# Altium schematic sheet Power Input and Protection for T to E.SchDoc("Power Input and Protection for T to E")
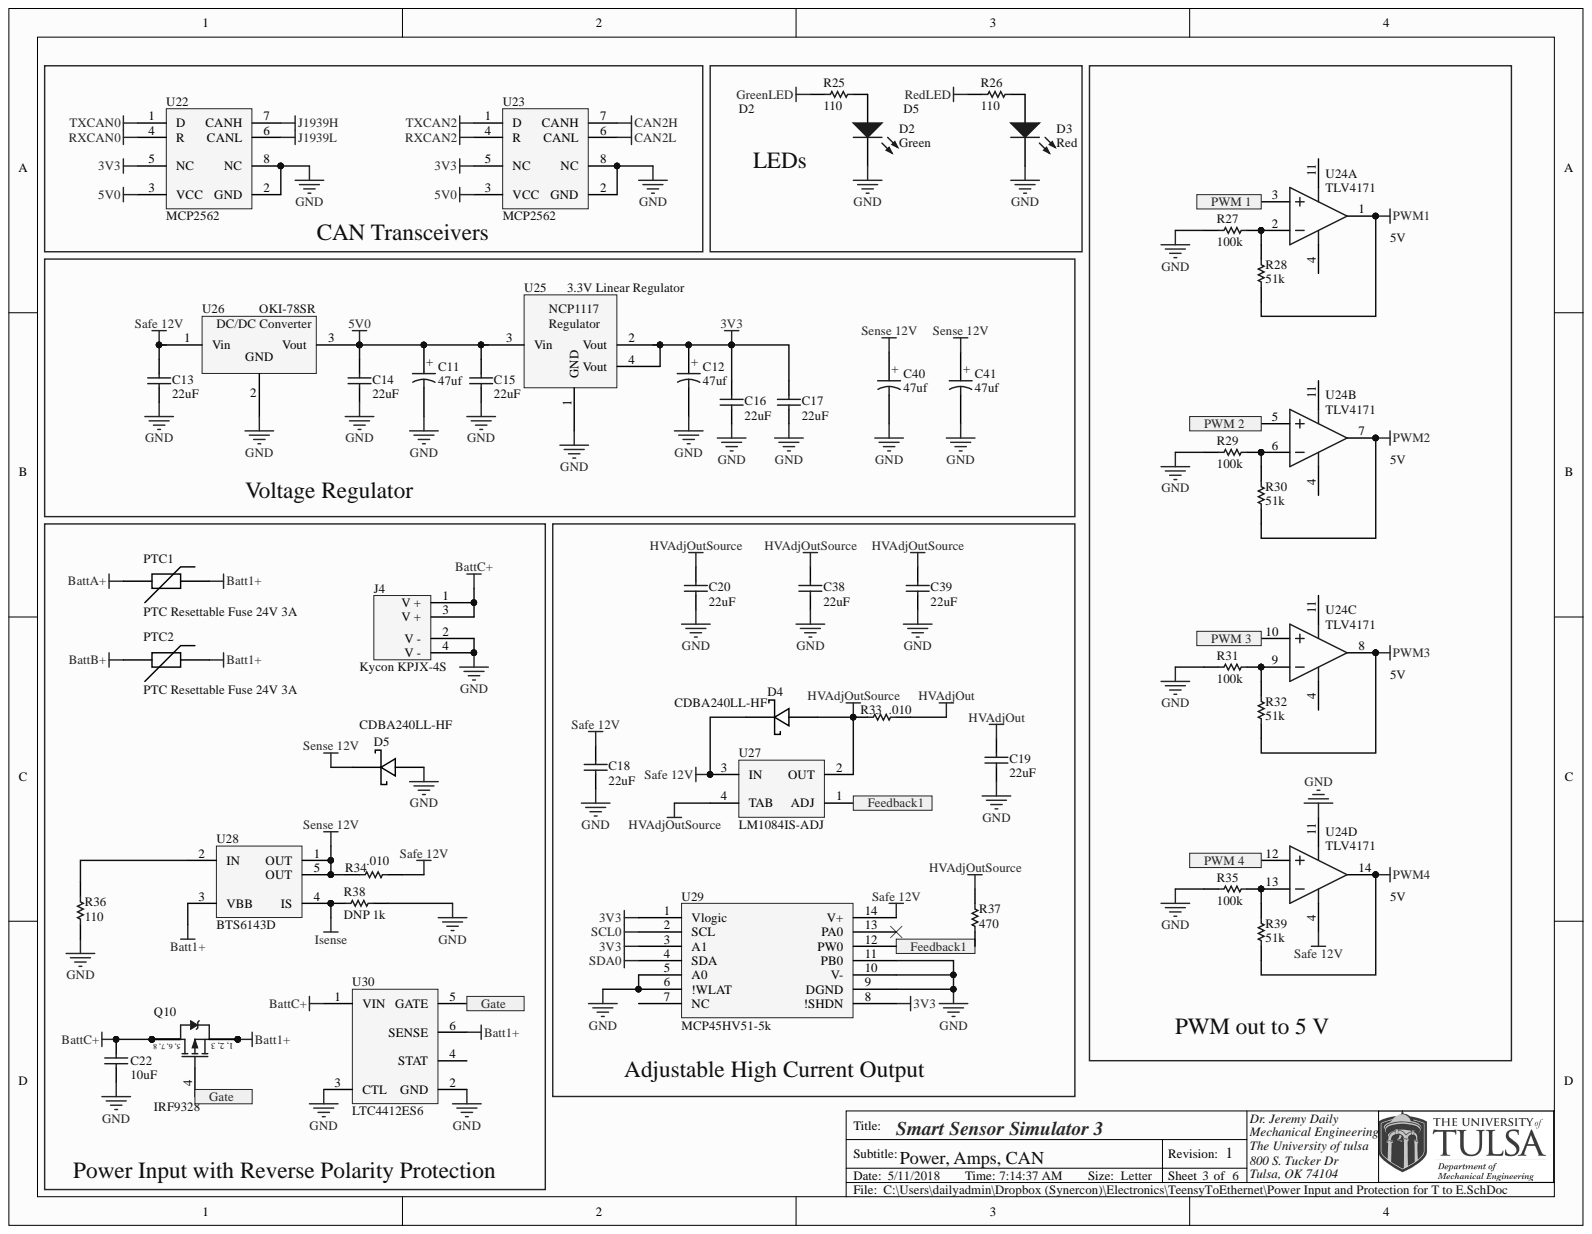
[diagram p0: Power Input and Protection for T to E.SchDoc]

## Parts
C11  47uf  [Elec. Cap 2.5 x 6.3mm]
C12  47uf  [Elec. Cap 2.5 x 6.3mm]
C13  22uF  [1206]
C14  22uF  [1206]
C15  22uF  [1206]
C16  22uF  [1206]
C17  22uF  [1206]
C18  22uF  [1206]
C19  22uF  [1206]
C20  22uF  [1206]
C22  10uF  [0603]
C38  22uF  [1206]
C39  22uF  [1206]
C40  47uf  [Elec. Cap 2.5 x 6.3mm]
C41  47uf  [Elec. Cap 2.5 x 6.3mm]
D2  Green  [0805 Polarized]
D3  Red  [0805 Polarized]
D4  CDBA240LL-HF  [SMA with A and K]
D5  CDBA240LL-HF  [SMA with A and K]
J4  Kycon KPJX-4S  Manufacturer: Kycon  [KPJX-4S-S]
PTC1  PTC Resettable Fuse 24V 3A  [2920]
PTC2  PTC Resettable Fuse 24V 3A  [2920]
Q10  IRF9328  [SOIC-8]
R25  110  [0603]
R26  110  [0603]
R27  100k  [0603]
R28  51k  [0603]
R29  100k  [0603]
R30  51k  [0603]
R31  100k  [0603]
R32  51k  [0603]
R33  .010  [0805]
R34  .010  [0805]
R35  100k  [0603]
R36  110  [0603]
R37  470  [0603]
R38  DNP 1k  [0603]
R39  51k  [0603]
U22  MCP2562  [SN65HVD232]
U23  MCP2562  [SN65HVD232]
U24  TLV4171  [TI-PW14_M]  (parts A,B,C,D)
U25  3.3V Linear Regulator  [SOT-223]
U26  OKI-78SR  [78xx]
U27  LM1084IS-ADJ  [TS3B_M]
U28  BTS6143D  [INF-PG-TO252-5-11_N]
U29  MCP45HV51-5k  [TSSOP-ST14_M]
U30  LTC4412ES6  [LT-S6-6-TSOT-23_M]

## Ports
Feedback1, Gate, PWM 1, PWM 2, PWM 3, PWM 4

## Nets
3V3: C12.1 C16.2 C17.2 U22.5(NC) U23.5(NC) U25.2(Vout) U25.4(Vout) U29.1(Vlogic) U29.3(A1) U29.8(!SHDN)
5V0: C11.1 C14.2 C15.2 U22.3(VCC) U23.3(VCC) U25.3(Vin) U26.3
Batt1+: PTC1.2 PTC2.2 Q10.1 Q10.2 Q10.3 U28.3(VBB) U30.6(SENSE)
BattA+: PTC1.1
BattB+: PTC2.1
BattC+: C22.1 J4.1(V_+) J4.3(V_+) Q10.5 Q10.6 Q10.7 Q10.8 U30.1(VIN)
CAN2H: U23.7(CANH)
CAN2L: U23.6(CANL)
GND: C11.2 C12.2 C13.1 C14.1 C15.1 C16.1 C17.1 C18.1 C19.1 C20.1 C22.2 C38.1 C39.1 C40.2 C41.2 D2.2 D3.2 D5.1 J4.2(V_-) J4.4(V_-) R27.2 R29.2 R31.2 R35.2 R36.1 R38.1 U22.2(GND) U22.8(NC) U23.2(GND) U23.8(NC) U24.11 U25.1(GND) U26.2 U29.5(A0) U29.6(!WLAT) U29.9(DGND) U29.10(V-) U29.11(PB0) U30.2(GND) U30.3(CTL)
GreenLED: R25.2
HVAdjOut: C19.2 R33.1
HVAdjOutSource: C20.2 C38.2 C39.2 D4.1 R33.2 R37.1 U27.2(OUT) U27.4(TAB)
Isense: R38.2 U28.4(IS)
J1939H: U22.7(CANH)
J1939L: U22.6(CANL)
PWM1: R28.2 U24.1
PWM2: R30.2 U24.7
PWM3: R32.2 U24.8
PWM4: R39.2 U24.14
RedLED: R26.2
RXCAN0: U22.4(R)
RXCAN2: U23.4(R)
Safe 12V: C13.2 C18.2 D4.2 R34.1 U24.4 U26.1 U27.3(IN) U29.14(V+)
SCL0: U29.2(SCL)
SDA0: U29.4(SDA)
Sense 12V: C40.1 C41.1 D5.2 R34.2 U28.1(OUT) U28.5(OUT)
TXCAN0: U22.1(D)
TXCAN2: U23.1(D)
Feedback1: R37.2 U27.1(ADJ) U29.12(PW0) ; port Feedback1
Gate: Q10.4 U30.5(GATE) ; port Gate
PWM 1: U24.3 ; port PWM 1
PWM 2: U24.5 ; port PWM 2
PWM 3: U24.10 ; port PWM 3
PWM 4: U24.12 ; port PWM 4
NetD2_1: D2.1 R25.1
NetD3_1: D3.1 R26.1
NetR27_1: R27.1 R28.1 U24.2
NetR29_1: R29.1 R30.1 U24.6
NetR31_1: R31.1 R32.1 U24.9
NetR35_1: R35.1 R39.1 U24.13
NetR36_2: R36.2 U28.2(IN)

Not connected: U29 7(NC) 13(PA0); U30 4(STAT)

## Library data of the parts
C11 C12 C40 C41 = Electrolytic Cap: 47µF 50V Aluminum Capacitors Radial, Can 5000 Hrs @ 105°C; PartNumber: EEU-EB1H470S; Manufacturer: Panasonic Electronic Components; Alternative: Acceptable; Supplier Part Number 1: P13464-ND; Supplier 1: Digi-Key; Library Name: SSS Integrated Library.IntLib; Component Kind: Standard
C13-C20 C38 C39 = Cap: 22µF ±20% 25V Ceramic Capacitor X5R 1206 (3216 Metric); PartNumber: 12063D226MAT2A; Manufacturer: AVX Corporation; Alternative: Acceptable; Supplier Part Number 1: 478-10040-1-ND; Supplier 1: Digi-Key; Simulation: CAP; Signal Integrity: Cap; Library Name: SSS Integrated Library.IntLib; Component Kind: Standard
C22 = Cap: 10µF ±20% 25V Ceramic Capacitor X5R 0603 (1608 Metric); PartNumber: ZRB18AR61E106ME01L; Manufacturer: Murata Electronics North America; Alternative: Acceptable; Supplier Part Number 1: 490-10991-1-ND; Supplier 1: Digi-Key; Simulation: CAP; Signal Integrity: Cap; Library Name: SSS Integrated Library.IntLib; Component Kind: Standard
D2 = LED - Green: Green 570nm LED Indication - Discrete 2.2V 0805 (2012 Metric); PartNumber: LG R971-KN-1; Manufacturer: OSRAM Opto Semiconductors Inc.; Alternative: None; Supplier Part Number 1: 475-1410-1-ND; Supplier 1: Digi-Key; Library Name: SSS Integrated Library.IntLib; Component Kind: Standard
D3 = LED - Red: Red 633nm LED Indication - Discrete 2V 0805 (2012 Metric); PartNumber: LS R976-NR-1; Manufacturer: OSRAM Opto Semiconductors Inc.; Alternative: None; Supplier Part Number 1: 475-1278-1-ND; Supplier 1: Digi-Key; Library Name: SSS Integrated Library.IntLib; Component Kind: Standard
D4 D5 = Schottky Diode: Diode Schottky 40V 2A Surface Mount DO-214AC (SMA); Manufacturer: Comchip Technology; Alternative: Acceptable; Supplier Part Number 1: 641-1698-1-ND; Supplier 1: Digi-Key; PartNumber: CDBA240LL-HF; Library Name: SSS Integrated Library.IntLib; Component Kind: Standard
J4 = Power Entry Jack - 4 Pin: DC Power Connectors 4P JACK SKT SHIELDED SNAP AND LOCK; Alternative: None; Supplier Part Number 1: 806-KPJX-4S-S; Supplier 1: Mouser; PartNumber: KPJX-4S-S; Library Name: SSS Integrated Library.IntLib; Component Kind: Standard
PTC1 PTC2 = PTC: PTC Resettable Fuse 24V 3A Ih Surface Mount 2920 (7351 Metric), Concave; PartNumber: MF-LSMF300/24X-2; Manufacturer: Bourns Inc.; Alternative: None; Supplier Part Number 1: MF-LSMF300/24X-2CT-ND; Supplier 1: Digi-Key; Library Name: SSS Integrated Library.IntLib; Component Kind: Standard
Q10 = IRF9328 P-FET: MOSFET P-CH 30V 12A 8-SOIC; PartNumber: IRF9328TRPBF; Manufacturer: Infineon Technologies; Alternative: None; Supplier Part Number 1: IRF9328TRPBFCT-ND; Supplier 1: Digi-Key; Library Name: SSS Integrated Library.IntLib; Component Kind: Standard
R25 R26 R36 = Chip Resistor: RES SMD 110 OHM 1% 1/10W 0603; PartNumber: ERJ-3EKF1100V; Manufacturer: Panasonic Electronic Components; Alternative: Acceptable; Supplier Part Number 1: P110HCT-ND; Supplier 1: Digi-Key; Library Name: SSS Integrated Library.IntLib; Component Kind: Standard
R27 R29 R31 R35 = Chip Resistor: 100 kOhms ±1% 0.1W, 1/10W Chip Resistor 0603 (1608 Metric) Automotive AEC-Q200 Thick Film; PartNumber: ERJ-3EKF1003V; Manufacturer: Panasonic Electronic Components; Alternative: Acceptable; Supplier Part Number 1: P100KHCT-ND; Supplier 1: Digi-Key; Library Name: SSS Integrated Library.IntLib; Component Kind: Standard
R28 R30 R32 R39 = Chip Resistor: RES SMD 51K OHM 1% 1/10W 0603; PartNumber: ERJ-3EKF5102V; Manufacturer: Panasonic Electronic Components; Alternative: Acceptable; Supplier Part Number 1: P51.0KHCT-ND; Supplier 1: Digi-Key; Library Name: SSS Integrated Library.IntLib; Component Kind: Standard
R33 R34 = Chip Resistor: 10 mOhms ±1% 0.5W, 1/2W Chip Resistor 0805 (2012 Metric) Automotive AEC-Q200, Current Sense Thick Film; PartNumber: ERJ-6BWFR010V; Manufacturer: Panasonic Electronic Components; Alternative: None; Supplier Part Number 1: 667-ERJ-6BWFR010V; Supplier 1: Mouser; Library Name: SSS Integrated Library.IntLib; Component Kind: Standard
R37 = Chip Resistor: RES SMD 470 OHM 1% 1/10W 0603; PartNumber: ERJ-3EKF4700V; Manufacturer: Panasonic Electronic Components; Alternative: Acceptable; Supplier Part Number 1: P470HCT-ND; Supplier 1: Digi-Key; Library Name: SSS Integrated Library.IntLib; Component Kind: Standard
R38 = Chip Resistor: RES SMD 1K OHM 1% 1/10W 0603; PartNumber: ERJ-3EKF1001V; Manufacturer: Panasonic Electronic Components; Alternative: Acceptable; Supplier Part Number 1: P1.00KHCT-ND; Supplier 1: Digi-Key; Library Name: SSS Integrated Library.IntLib; Component Kind: Standard
U22 U23 = SN65HVD232D: IC TXRX CAN HIGH SPEED VIO 8SOIC; PartNumber: MCP2562FD-E/SN; Manufacturer: Microchip Technology; Alternative: None; Supplier Part Number 1: MCP2562FD-E/SN-ND; Supplier 1: Digi-Key; Library Name: Texas Instruments Interface CAN.IntLib; Component Kind: Standard
U24 = TL064CPW: Quad Low-Power JFET-Input General-Purpose Operational Amplifier, 7 to 36 V, 0 to 70 degC, 14-pin SOP (PW14), Green (RoHS & no Sb/Br); PartNumber: TLV4171IPWR; Manufacturer: Texas Instruments; Alternative: None; Supplier Part Number 1: 296-44866-1-ND; Supplier 1: Digi-Key; Library Name: TI Amplifiers and Linear Operational Amplifier General Purpose.IntLib; Component Kind: Standard
U25 = NCP1117 2.5V: Linear Voltage Regulator IC Positive Fixed 1 Output 3.3V 1A SOT-223; PartNumber: NCP1117LPST33T3G; Manufacturer: ON Semiconductor; Alternative: None; Supplier Part Number 1: NCP1117LPST33T3GOSDKR-ND; Supplier 1: Digi-Key; Library Name: SSS Integrated Library.IntLib; Component Kind: Standard
U26 = 7805: Linear Regulator Replacement DC DC Converter 1 Output 5V 1.5A 7V - 36V Input; PartNumber: OKI-78SR-5/1.5-W36-C; Manufacturer: Murata Power Solutions; Alternative: None; Supplier Part Number 1: 811-2196-5-ND; Supplier 1: Digi-Key; Library Name: SSS Integrated Library.IntLib; Component Kind: Standard
U27 = LM1084IS-ADJ: Linear Voltage Regulator IC Positive Adjustable 1 Output 1.2 V ~ 15 V 5A DDPAK/TO-263-3; PartNumber: LM1084IS-ADJ/NOPB; Manufacturer: Texas Instruments; Alternative: None; Supplier Part Number 1: LM1084IS-ADJ/NOPB-ND; Supplier 1: Digi-Key; Library Name: National Semiconductor LDO.IntLib; Component Kind: Standard
U28 = BTS6143D: PROFET Smart Highside Power Switch, 1 Channel, 10 A Load Current, -40 to 150 degC, PG-TO252-5-11, Reel, Green; PartNumber: BTS6143DAUMA1; Manufacturer: Infineon; Alternative: None; Supplier Part Number 1: BTS6143DAUMA1CT-ND; Supplier 1: Digi-Key; Library Name: Infineon_Power_Smart_Low-Side_&_High-Side_Switches.IntLib; Component Kind: Standard
U29 = MCP45HVX1 Digital Pot: Digital Potentiometer 5k Ohm 1 Circuit 256 Taps I²C Interface 14-TSSOP; PartNumber: MCP45HV51-502E/ST; Manufacturer: Microchip Technology; Alternative: None; Supplier Part Number 1: MCP45HV51-502E/ST-ND; Supplier 1: Digi-Key; Library Name: SSS Integrated Library.IntLib; Component Kind: Standard
U30 = LTC4412ES6: Low Loss PowerPath(TM) Controller, 2.5 to 28 V Vin, 6-pin SOT23 (S6-6), -40 to 85 degC; PartNumber: LTC4412HVIS6#TRMPBF; Manufacturer: Linear Technology; Alternative: None; Supplier Part Number 1: LTC4412HVIS6#TRMPBFCT-ND; Supplier 1: Digi-Key; Library Name: Linear Technology Power Management Power Control.IntLib; Component Kind: Standard
